# Altium schematic sheet VHF_Control.SchDoc
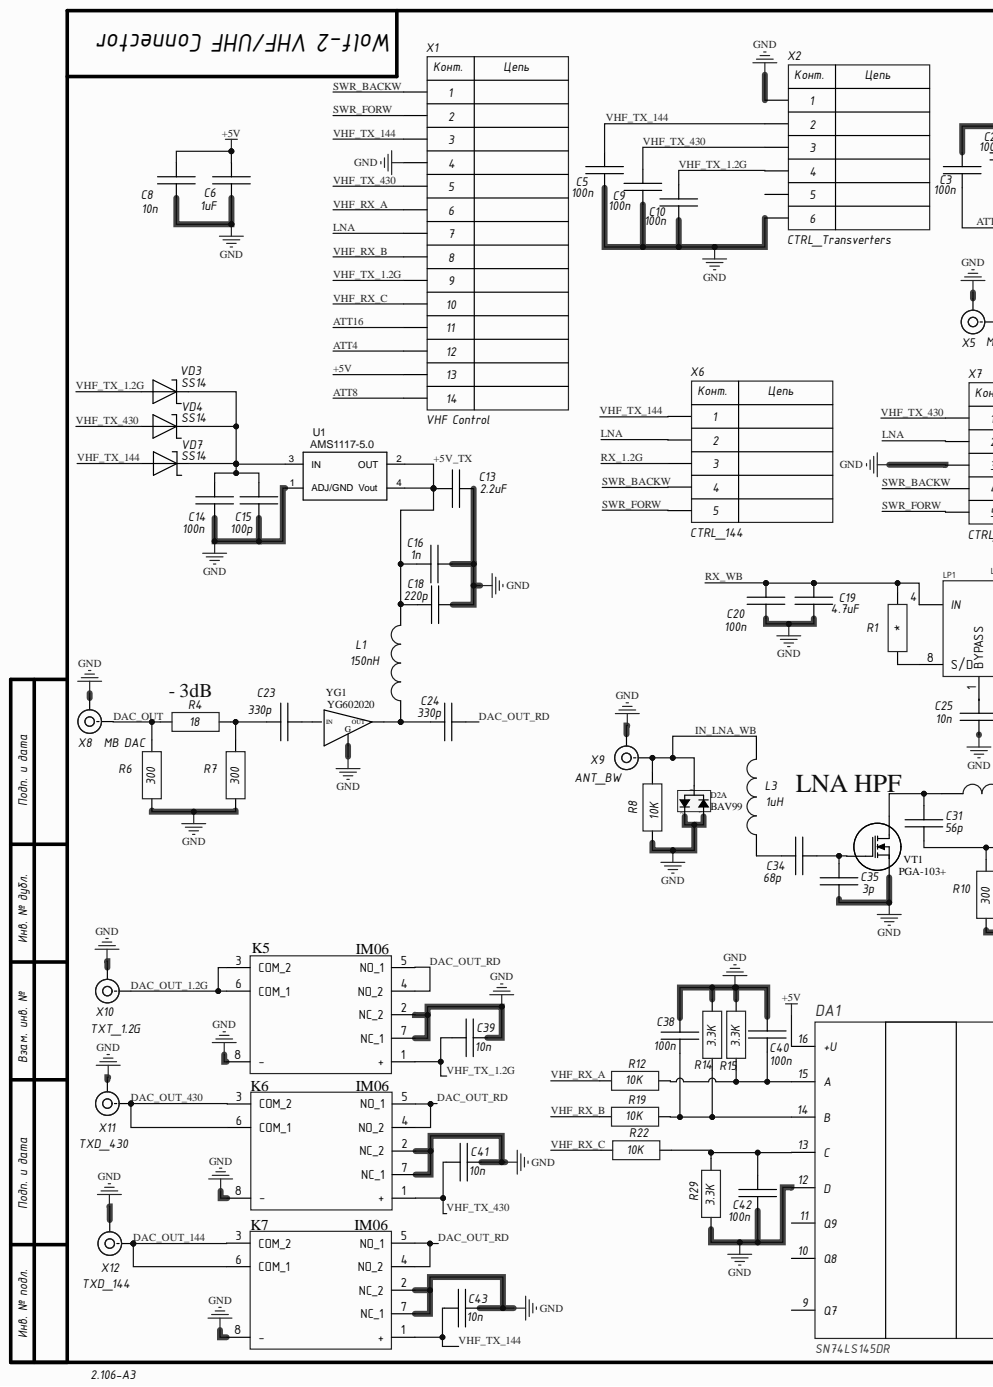
[diagram p0: VHF_Control.SchDoc, left]
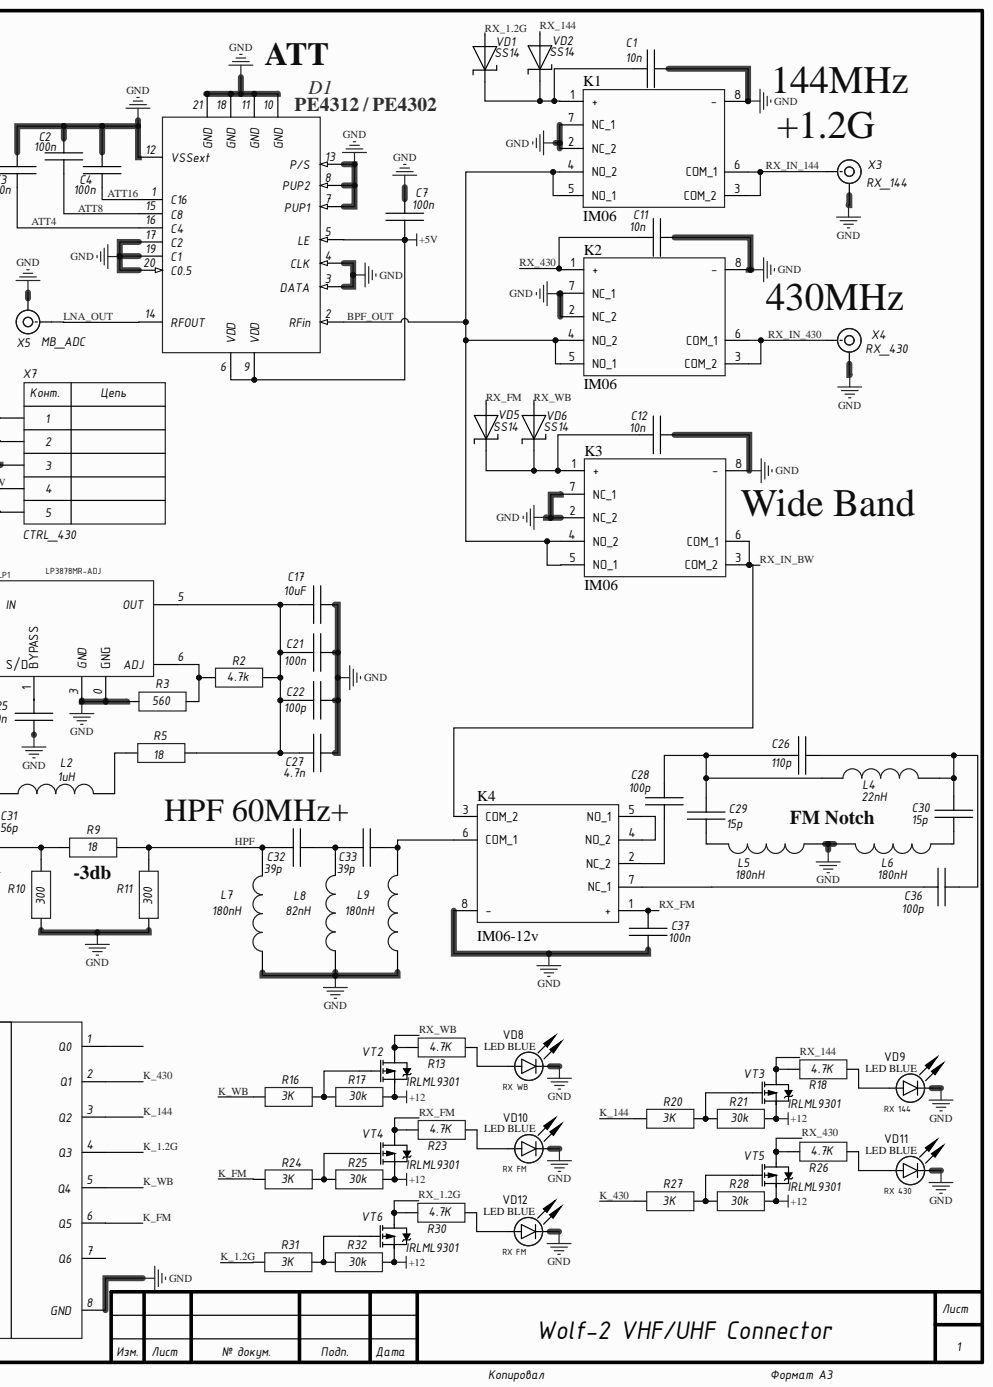
[diagram p1: VHF_Control.SchDoc, right]

## Parts
C1  10n  [CH0805C]
C2  100n  [CH0805C]
C3  100n  [CH0805C]
C4  100n  [CH0805C]
C5  100n  [CH0805C]
C6  1uF  [CH0805C]
C7  100n  [CH0805C]
C8  10n  [CH0805C]
C9  100n  [CH0805C]
C10  100n  [CH0805C]
C11  10n  [CH0805C]
C12  10n  [CH0805C]
C13  2.2uF  [CH0805C]
C14  100n  [CH0805C]
C15  100p  [CH0805C]
C16  1n  [CH0805C]
C17  10uF  [CH0805C]
C18  220p  [CH0805C]
C19  4.7uF  [CH0805C]
C20  100n  [CH0805C]
C21  100n  [CH0805C]
C22  100p  [CH0805C]
C23  330p  [CH0805C]
C24  330p  [CH0805C]
C25  10n  [CH0805C]
C26  110p  [CH0805C]
C27  4.7n  [CH0805C]
C28  100p  [CH0805C]
C29  15p  [CH0805C]
C30  15p  [CH0805C]
C31  56p  [CH0805C]
C32  39p  [CH0805C]
C33  39p  [CH0805C]
C34  68p  [CH0805C]
C35  3p  [CH0805C]
C36  100p  [CH0805C]
C37  100n  [CH0805C]
C38  100n  [CH0805C]
C39  10n  [CH0805C]
C40  100n  [CH0805C]
C41  10n  [CH0805C]
C42  100n  [CH0805C]
C43  10n  [CH0805C]
D1  PE4312 / PE4302  [20-QFN (4x4)]
D2  BAV99  [SOT23_132]  (part A)
DA1  SN74LS145DR  [16-SOP]
K1  IM06  [IM06]
K2  IM06  [IM06]
K3  IM06  [IM06]
K4  IM06-12v  [IM06]
K5  IM06  [IM06]
K6  IM06  [IM06]
K7  IM06  [IM06]
L1  150nH  [CH1210L]
L2  1uH  [CH1210L]
L3  1uH  [CH1210L]
L4  22nH  [CH1206L]
L5  180nH  [CH1206L]
L6  180nH  [CH1206L]
L7  180nH  [CH1206L]
L8  82nH  [CH1206L]
L9  180nH  [CH1206L]
LP1  LP3878MR-ADJ  [SOP-8 gnd]
R1  (no value)  [CH0805R]
R2  4.7k  [CH0805R]
R3  560  [CH0805R]
R4  18  [CH0805R]
R5  18  [CH2010R]
R6  300  [CH0805R]
R7  300  [CH0805R]
R8  10K  [CH2010R]
R9  18  [CH0805R]
R10  300  [CH0805R]
R11  300  [CH0805R]
R12  10K  [CH0805R]
R13  4.7K  [CH0805R]
R14  3.3K  [CH0805R]
R15  3.3K  [CH0805R]
R16  3K  [CH0805R]
R17  30k  [CH0805R]
R18  4.7K  [CH0805R]
R19  10K  [CH0805R]
R20  3K  [CH0805R]
R21  30k  [CH0805R]
R22  10K  [CH0805R]
R23  4.7K  [CH0805R]
R24  3K  [CH0805R]
R25  30k  [CH0805R]
R26  4.7K  [CH0805R]
R27  3K  [CH0805R]
R28  30k  [CH0805R]
R29  3.3K  [CH0805R]
R30  4.7K  [CH0805R]
R31  3K  [CH0805R]
R32  30k  [CH0805R]
U1  1117-5.0  value AMS1117-5.0  [LT1117CST-3.3]
VD1  SS14  [SMA]
VD2  SS14  [SMA]
VD3  SS14  [SMA]
VD4  SS14  [SMA]
VD5  SS14  [SMA]
VD6  SS14  [SMA]
VD7  SS14  [SMA]
VD8  LED BLUE  Color: RX WB  TypeDiode: LED  [LED: 0805B]
VD9  LED BLUE  Color: RX 144  TypeDiode: LED  [LED: 0805B]
VD10  LED BLUE  Color: RX FM  TypeDiode: LED  [LED: 0805B]
VD11  LED BLUE  Color: RX 430  TypeDiode: LED  [LED: 0805B]
VD12  LED BLUE  Color: RX FM  TypeDiode: LED  [LED: 0805B]
VT1  PGA-103+  [SOT89_321_PGA103]
VT2  IRLML9301  [SOT23_132]
VT3  IRLML9301  [SOT23_132]
VT4  IRLML9301  [SOT23_132]
VT5  IRLML9301  [SOT23_132]
VT6  IRLML9301  [SOT23_132]
X1  VHF Control  [CON: IDC-14MS]
X2  CTRL_Transverters  [CON: PBS-6]
X3  RX_144  [CON: u.Fl]
X4  RX_430  [CON: u.Fl]
X5  MB_ADC  [CON: SMA]
X6  CTRL_144  [CON: PLS-5]
X7  CTRL_430  [CON: PLS-5]
X8  MB DAC  [CON: SMA]
X9  ANT_BW  [CON: SMA]
X10  TXT_1.2G  [CON: SMA]
X11  TXD_430  [CON: u.Fl]
X12  TXD_144  [CON: u.Fl]
YG1  YG602020  [SOT89_123]

## Nets
+12: R17.1 R21.1 R25.1 R28.1 R32.1 VT2.2 VT3.2 VT4.2 VT5.2 VT6.2
GND: C1.2 C2.1 C3.1 C4.1 C5.1 C6.1 C7.2 C8.1 C9.1 C10.1 C11.2 C12.2 C13.2 C14.2 C15.2 C16.1 C17.2 C18.1 C19.2 C20.2 C21.2 C22.2 C25.2 C27.2 C35.2 C37.1 C38.1 C39.1 C40.1 C41.1 C42.2 C43.1 D1.3(DATA) D1.4(CLK) D1.7(PUP1) D1.8(PUP2) D1.10(GND) D1.11(GND) D1.12(VSSext) D1.13(P/S) D1.17(C2) D1.18(GND) D1.19(C1) D1.20(С0.5) D1.21(GND) D2.1 D2.2 DA1.8(GND) DA1.12(D) K1.2(NC_2) K1.7(NC_1) K1.8(-) K2.2(NC_2) K2.7(NC_1) K2.8(-) K3.2(NC_2) K3.7(NC_1) K3.8(-) K4.8(-) K5.2(NC_2) K5.7(NC_1) K5.8(-) K6.2(NC_2) K6.7(NC_1) K6.8(-) K7.2(NC_2) K7.7(NC_1) K7.8(-) L5.2 L6.1 L7.2 L8.2 L9.2 LP1.0(GNG) LP1.3(GND) R3.2 R6.2 R7.2 R8.1 R10.2 R11.2 R14.1 R15.1 R29.2 U1.1(ADJ/GND) VD8.2 VD9.2 VD10.2 VD11.2 VD12.2 VT1.2 X1.4 X2.1 X2.6 X3.2 X4.2 X5.2 X7.3 X8.2 X9.2 X10.2 X11.2 X12.2 YG1.2
+5V: C6.2 C7.1 C8.2 D1.5(LE) D1.6(VDD) D1.9(VDD) DA1.16(+U) X1.13
+5V_TX: C13.1 C16.2 C18.2 L1.1 U1.2(OUT) U1.4(Vout)
ATT4: C3.2 D1.16(C4) X1.12
ATT8: C2.2 D1.15(C8) X1.14
ATT16: C4.2 D1.1(C16) X1.11
BPF_OUT: D1.2(RFin) K1.4(NO_2) K1.5(NO_1) K2.4(NO_2) K2.5(NO_1) K3.4(NO_2) K3.5(NO_1)
DAC_OUT: R4.2 R6.1 X8.1
DAC_OUT_1.2G: K5.3(COM_2) K5.6(COM_1) X10.1
DAC_OUT_144: K7.3(COM_2) K7.6(COM_1) X12.1
DAC_OUT_430: K6.3(COM_2) K6.6(COM_1) X11.1
DAC_OUT_RD: C24.1 K5.4(NO_2) K5.5(NO_1) K6.4(NO_2) K6.5(NO_1) K7.4(NO_2) K7.5(NO_1)
HPF: C32.2 L7.1 R9.1 R11.1
IN_LNA_WB: D2.3 L3.1 R8.2 X9.1
K_1.2G: DA1.4(Q3) R31.2
K_144: DA1.3(Q2) R20.2
K_430: DA1.2(Q1) R27.2
K_FM: DA1.6(Q5) R24.2
K_WB: DA1.5(Q4) R16.2
LNA: X1.7 X6.2 X7.2
LNA_OUT: D1.14(RFOUT) X5.1
RX_1.2G: R30.2 VD1.1 VT6.3 X6.3
RX_144: R18.2 VD2.1 VT3.3
RX_430: C11.1 K2.1(+) R26.2 VT5.3
RX_FM: C37.2 K4.1(+) R23.2 VD5.1 VT4.3
RX_IN_144: K1.3(COM_2) K1.6(COM_1) X3.1
RX_IN_430: K2.3(COM_2) K2.6(COM_1) X4.1
RX_IN_BW: K3.3(COM_2) K3.6(COM_1) K4.3(COM_2)
RX_WB: C19.1 C20.1 LP1.4(IN) R1.1 R13.2 VD6.1 VT2.3
SWR_BACKW: X1.1 X6.4 X7.4
SWR_FORW: X1.2 X6.5 X7.5
VHF_RX_A: R12.2 X1.6
VHF_RX_B: R19.2 X1.8
VHF_RX_C: R22.2 X1.10
VHF_TX_1.2G: C10.2 C39.2 K5.1(+) VD3.1 X1.9 X2.4
VHF_TX_144: C5.2 C43.2 K7.1(+) VD7.1 X1.3 X2.2 X6.1
VHF_TX_430: C9.2 C41.2 K6.1(+) VD4.1 X1.5 X2.3 X7.1
NetC1_1: C1.1 K1.1(+) VD1.2 VD2.2
NetC12_1: C12.1 K3.1(+) VD5.2 VD6.2
NetC14_1: C14.1 C15.1 U1.3(IN) VD3.2 VD4.2 VD7.2
NetC17_1: C17.1 C21.1 C22.1 C27.1 LP1.5(OUT) R2.1 R5.1
NetC23_1: C23.1 YG1.1
NetC23_2: C23.2 R4.1 R7.1
NetC24_2: C24.2 L1.2 YG1.3
NetC25_1: C25.1 LP1.1(BYPASS)
NetC26_1: C26.1 C30.2 C36.2 L4.1
NetC26_2: C26.2 C28.1 C29.2 L4.2
NetC28_2: C28.2 K4.2(NC_2)
NetC29_1: C29.1 L5.1
NetC30_1: C30.1 L6.2
NetC31_1: C31.1 L2.2 VT1.1
NetC31_2: C31.2 R9.2 R10.1
NetC32_1: C32.1 C33.2 L8.1
NetC33_1: C33.1 K4.6(COM_1) L9.1
NetC34_1: C34.1 C35.1 VT1.3
NetC34_2: C34.2 L3.2
NetC36_1: C36.1 K4.7(NC_1)
NetC38_2: C38.2 DA1.14(B) R14.2 R19.1
NetC40_2: C40.2 DA1.15(A) R12.1 R15.2
NetC42_1: C42.1 DA1.13(C) R22.1 R29.1
NetK4_4: K4.4(NO_2) K4.5(NO_1)
NetL2_1: L2.1 R5.2
NetLP1_6: LP1.6(ADJ) R2.2 R3.1
NetLP1_8: LP1.8(S/D) R1.2
NetR13_1: R13.1 VD8.1
NetR16_1: R16.1 R17.2 VT2.1
NetR18_1: R18.1 VD9.1
NetR20_1: R20.1 R21.2 VT3.1
NetR23_1: R23.1 VD10.1
NetR24_1: R24.1 R25.2 VT4.1
NetR26_1: R26.1 VD11.1
NetR27_1: R27.1 R28.2 VT5.1
NetR30_1: R30.1 VD12.1
NetR31_1: R31.1 R32.2 VT6.1

Not connected: DA1 1(Q0) 7(Q6) 9(Q7) 10(Q8) 11(Q9); X2 5

## Library data of the parts
C1-C43 = Capacitor: Component Kind: Standard; Library Name: Discrete.SchLib
D1 = PE4312-№1: Component Kind: Standard; Library Name: Digital.SchLib
DA1 = SN74LS145N: Component Kind: Standard; Library Name: Digital.SchLib
K1-K7 = IM06-V1: Component Kind: Standard; Library Name: Relay.SchLib
L1-L9 = Inductor: Component Kind: Standard; Library Name: Discrete.SchLib
LP1 = LP3878-ADJ: LP3878-ADJ HSOP-8; Component Kind: Standard; Library Name: RU4PN.SchLib
R1-R32 = Resistor: Component Kind: Standard; Library Name: Discrete.SchLib
U1 = LT1117CST-3.3: LT1117CST-5.0; Component Kind: Standard
VD1-VD7 = Diod Schottky: Component Kind: Standard; Library Name: Diods.SchLib
VD8-VD12 = LED_single: ValueManufacturerPartNumber: LED, *, *, *, -55...+150°C; Component Kind: Standard; Library Name: Diode.SchLib; Temperature: -55...+150°C
VT1 = N: Component Kind: Standard; Library Name: Transistor.SchLib
VT2-VT6 = IRLML9301: Mosfet; Component Kind: Standard; Library Name: Transistor.SchLib
X1 = 14-Connector: Component Kind: Standard; Library Name: Connectors.SchLib
X2 = 6-Connector: Component Kind: Standard; Library Name: Connectors.SchLib
X3 X4 X11 X12 = u.Fl: RF; Manufacturer: Hirose Electric Co Ltd; Model: U.FL-R-SMT(01); Component Kind: Standard; Library Name: Connectors.SchLib
X5 X8-X10 = SMA: RF; Component Kind: Standard; Library Name: Connectors.SchLib
X6 X7 = 5-Connector: Component Kind: Standard; Library Name: Connectors.SchLib
D2 = BAV99: Component Kind: Standard; Library Name: Analog.SchLib
YG1 = YG602020: Component Kind: Standard; Library Name: RU4PN.SchLib
